# Altium schematic sheet Power and Data.SchDoc("Power and Data")
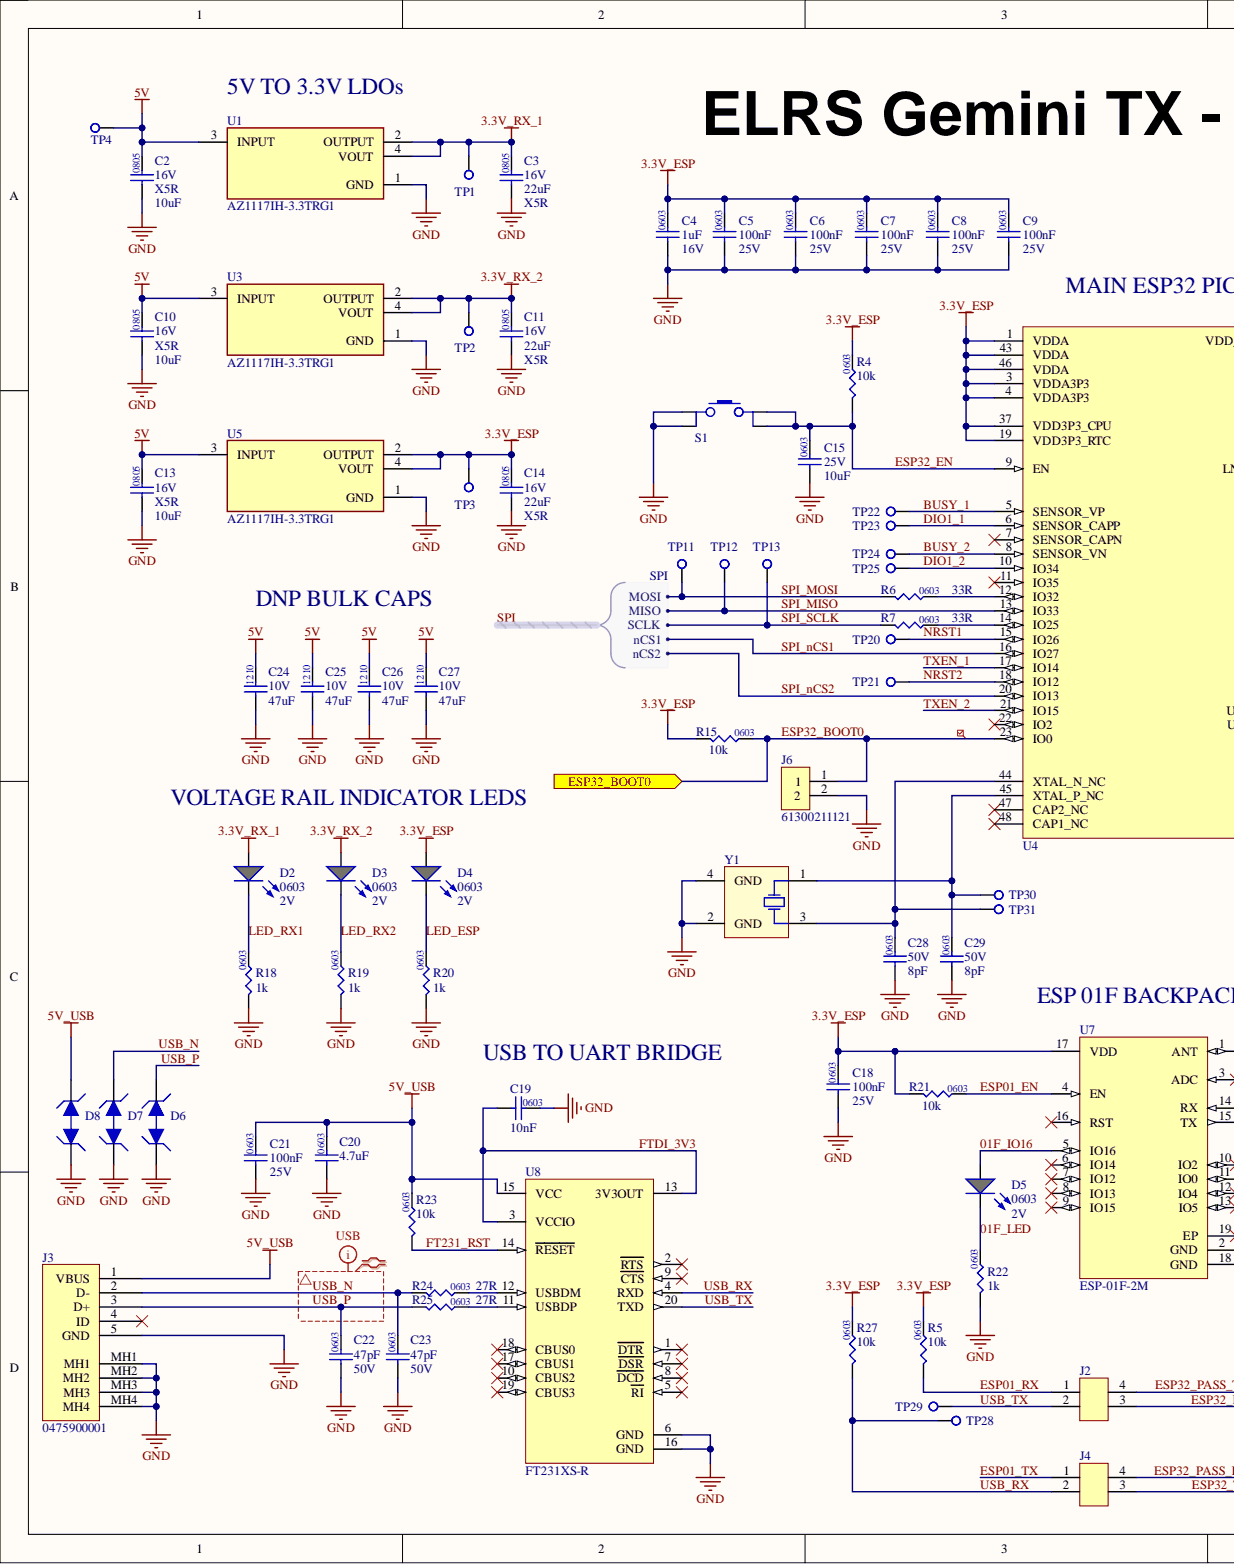
[diagram p0: Power and Data.SchDoc, left]
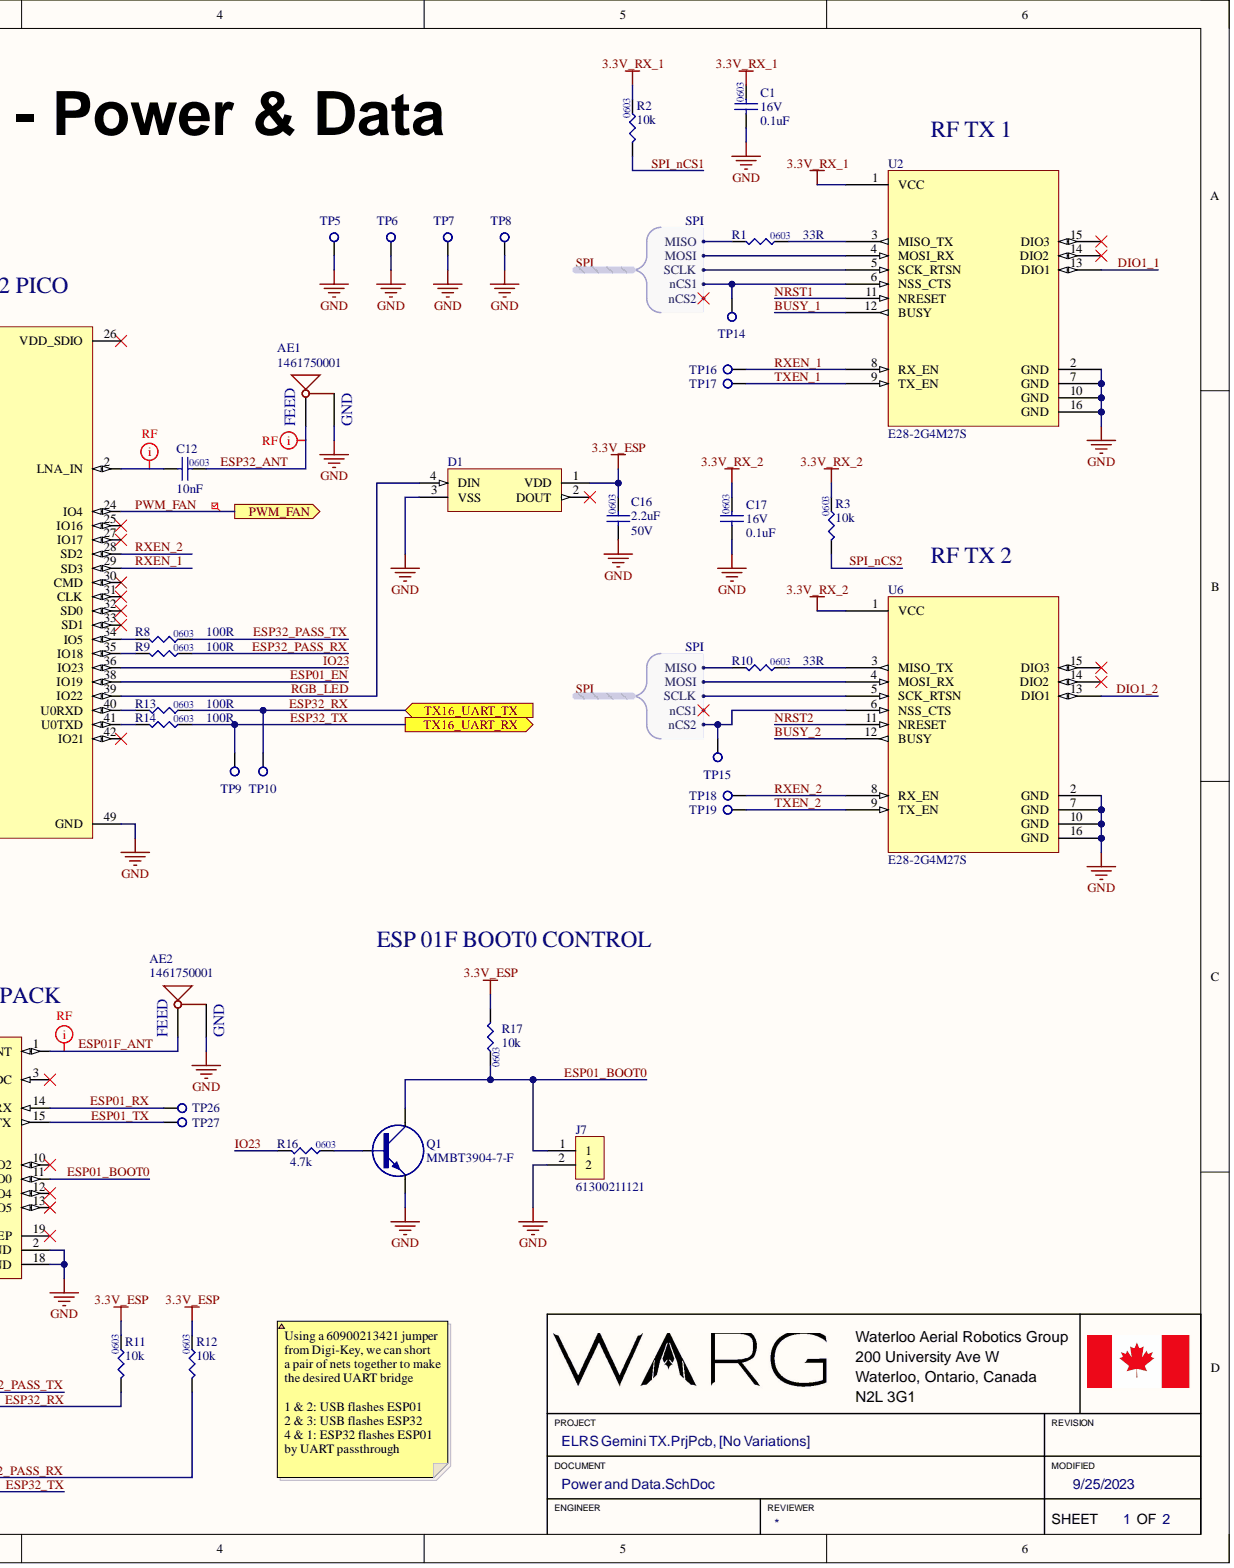
[diagram p1: Power and Data.SchDoc, right]

## Parts
AE1  1461750001
AE2  1461750001
C1  value 0.1uF  Capactitance: 0.1uF  Package: 0603  Voltage: 16V  (hidden comment: GCM188R71C104KA37D)
C2  value 10uF  Capacitance: 10uF  Package: 0805  Temperature Coefficient: X5R  Voltage: 16V  (hidden comment: CL21A106KOQNNNE)
C3  value 22uF  Capacitance: 22uF  Package: 0805  Temperature Coefficient: X5R  Voltage: 16V  (hidden comment: CL21A226MOQNNNE)
C4  value 1uF  Capacitance: 1uF  Package: 0603  Voltage: 16V  (hidden comment: GCM188R71C105KA64J)
C5  Capacitance: 100nF  Case/Package: 0603  Voltage Rating: 25V  (hidden comment: CL10B104KA8NNNC)
C6  Capacitance: 100nF  Case/Package: 0603  Voltage Rating: 25V  (hidden comment: CL10B104KA8NNNC)
C7  Capacitance: 100nF  Case/Package: 0603  Voltage Rating: 25V  (hidden comment: CL10B104KA8NNNC)
C8  Capacitance: 100nF  Case/Package: 0603  Voltage Rating: 25V  (hidden comment: CL10B104KA8NNNC)
C9  Capacitance: 100nF  Case/Package: 0603  Voltage Rating: 25V  (hidden comment: CL10B104KA8NNNC)
C10  value 10uF  Capacitance: 10uF  Package: 0805  Temperature Coefficient: X5R  Voltage: 16V  (hidden comment: CL21A106KOQNNNE)
C11  value 22uF  Capacitance: 22uF  Package: 0805  Temperature Coefficient: X5R  Voltage: 16V  (hidden comment: CL21A226MOQNNNE)
C12  value 10nF  Capacitance: 10nF  Package: 0603  (hidden comment: CC0603KRX7R7BB103)
C13  value 10uF  Capacitance: 10uF  Package: 0805  Temperature Coefficient: X5R  Voltage: 16V  (hidden comment: CL21A106KOQNNNE)
C14  value 22uF  Capacitance: 22uF  Package: 0805  Temperature Coefficient: X5R  Voltage: 16V  (hidden comment: CL21A226MOQNNNE)
C15  value 10uF  Case/Package: 0603  Voltage Rating: 25V  (hidden comment: GRM188R61E106KA73J)
C16  value 2.2uF  Package: 0603  Voltage Rating: 50V  (hidden comment: GRM188R61H225KE11D)
C17  value 0.1uF  Capactitance: 0.1uF  Package: 0603  Voltage: 16V  (hidden comment: GCM188R71C104KA37D)
C18  Capacitance: 100nF  Case/Package: 0603  Voltage Rating: 25V  (hidden comment: CL10B104KA8NNNC)
C19  value 10nF  Capacitance: 10nF  Package: 0603  (hidden comment: CC0603KRX7R7BB103)
C20  value 4.7uF  Capacitance: 4.7uF  Package: 0603  (hidden comment: GRM188C81E475KE11D)
C21  Capacitance: 100nF  Case/Package: 0603  Voltage Rating: 25V  (hidden comment: CL10B104KA8NNNC)
C22  value 47pF  Case/Package: 0603  Voltage Rating: 50V  (hidden comment: CL10C470JB8NNNC)
C23  value 47pF  Case/Package: 0603  Voltage Rating: 50V  (hidden comment: CL10C470JB8NNNC)
C24  value 47uF  Voltage Rating: 10V  (hidden comment: GRM32EC81A476KE19L)
C25  value 47uF  Voltage Rating: 10V  (hidden comment: GRM32EC81A476KE19L)
C26  value 47uF  Voltage Rating: 10V  (hidden comment: GRM32EC81A476KE19L)
C27  value 47uF  Voltage Rating: 10V  (hidden comment: GRM32EC81A476KE19L)
C28  Capacitance: 8pF  Package: 0603  Voltage Rating: 50V  (hidden comment: CC0603BRNPO9BN8R0)
C29  Capacitance: 8pF  Package: 0603  Voltage Rating: 50V  (hidden comment: CC0603BRNPO9BN8R0)
D1  COM-16347  (value not drawn)
D2  Case/Package: 0603  Forward Voltage: 2V  (hidden comment: LTST-C191KGKT)
D3  Case/Package: 0603  Forward Voltage: 2V  (hidden comment: LTST-C191KGKT)
D4  Case/Package: 0603  Forward Voltage: 2V  (hidden comment: LTST-C191KGKT)
D5  Case/Package: 0603  Forward Voltage: 2V  (hidden comment: LTST-C191KGKT)
D6  DESD5V0U1BA-7  (value not drawn)
D7  DESD5V0U1BA-7  (value not drawn)
D8  DESD5V0U1BA-7  (value not drawn)
J2  M20-9760246  (value not drawn)
J3  0475900001
J4  M20-9760246  (value not drawn)
J6  61300211121
J7  61300211121
Q1  MMBT3904-7-F
R1  value 33R  Case/Package: 0603  (hidden comment: RC0603FR-0733RL)
R2  value 10k  Package: 0603  Resistance: 10k  (hidden comment: RC0603FR-0710KL)
R3  value 10k  Package: 0603  Resistance: 10k  (hidden comment: RC0603FR-0710KL)
R4  value 10k  Package: 0603  Resistance: 10k  (hidden comment: RC0603FR-0710KL)
R5  value 10k  Package: 0603  Resistance: 10k  (hidden comment: RC0603FR-0710KL)
R6  value 33R  Case/Package: 0603  (hidden comment: RC0603FR-0733RL)
R7  value 33R  Case/Package: 0603  (hidden comment: RC0603FR-0733RL)
R8  Case Code (Imperial): 0603  Resistance: 100R  (hidden comment: RC0603FR-07100RL)
R9  Case Code (Imperial): 0603  Resistance: 100R  (hidden comment: RC0603FR-07100RL)
R10  value 33R  Case/Package: 0603  (hidden comment: RC0603FR-0733RL)
R11  value 10k  Package: 0603  Resistance: 10k  (hidden comment: RC0603FR-0710KL)
R12  value 10k  Package: 0603  Resistance: 10k  (hidden comment: RC0603FR-0710KL)
R13  Case Code (Imperial): 0603  Resistance: 100R  (hidden comment: RC0603FR-07100RL)
R14  Case Code (Imperial): 0603  Resistance: 100R  (hidden comment: RC0603FR-07100RL)
R15  value 10k  Package: 0603  Resistance: 10k  (hidden comment: RC0603FR-0710KL)
R16  value 4.7k  Case/Package: 0603  Resistance: 4.7k  (hidden comment: RC0603JR-074K7L)
R17  value 10k  Package: 0603  Resistance: 10k  (hidden comment: RC0603FR-0710KL)
R18  value 1k  Case/Package: 0603  (hidden comment: RC0603FR-071KL)
R19  value 1k  Case/Package: 0603  (hidden comment: RC0603FR-071KL)
R20  value 1k  Case/Package: 0603  (hidden comment: RC0603FR-071KL)
R21  value 10k  Package: 0603  Resistance: 10k  (hidden comment: RC0603FR-0710KL)
R22  value 1k  Case/Package: 0603  (hidden comment: RC0603FR-071KL)
R23  value 10k  Package: 0603  Resistance: 10k  (hidden comment: RC0603FR-0710KL)
R24  Package Name: 0603  (hidden comment: RC0603FR-0727RL)
R25  Package Name: 0603  (hidden comment: RC0603FR-0727RL)
R27  value 10k  Package: 0603  Resistance: 10k  (hidden comment: RC0603FR-0710KL)
S1  SWITCH_SPST_NO  (value not drawn)
TP1  RCWCTE  (value not drawn)
TP2  RCWCTE  (value not drawn)
TP3  RCWCTE  (value not drawn)
TP4  RCWCTE  (value not drawn)
TP5  RCWCTE  (value not drawn)
TP6  RCWCTE  (value not drawn)
TP7  RCWCTE  (value not drawn)
TP8  RCWCTE  (value not drawn)
TP9  TESTINGPOINT_1MM  (value not drawn)
TP10  TESTINGPOINT_1MM  (value not drawn)
TP11  TESTINGPOINT_1MM  (value not drawn)
TP12  TESTINGPOINT_1MM  (value not drawn)
TP13  TESTINGPOINT_1MM  (value not drawn)
TP14  TESTINGPOINT_1MM  (value not drawn)
TP15  TESTINGPOINT_1MM  (value not drawn)
TP16  TESTINGPOINT_1MM  (value not drawn)
TP17  TESTINGPOINT_1MM  (value not drawn)
TP18  TESTINGPOINT_1MM  (value not drawn)
TP19  TESTINGPOINT_1MM  (value not drawn)
TP20  TESTINGPOINT_1MM  (value not drawn)
TP21  TESTINGPOINT_1MM  (value not drawn)
TP22  TESTINGPOINT_1MM  (value not drawn)
TP23  TESTINGPOINT_1MM  (value not drawn)
TP24  TESTINGPOINT_1MM  (value not drawn)
TP25  TESTINGPOINT_1MM  (value not drawn)
TP26  TESTINGPOINT_1MM  (value not drawn)
TP27  TESTINGPOINT_1MM  (value not drawn)
TP28  TESTINGPOINT_1MM  (value not drawn)
TP29  TESTINGPOINT_1MM  (value not drawn)
TP30  TESTINGPOINT_1MM  (value not drawn)
TP31  TESTINGPOINT_1MM  (value not drawn)
U1  AZ1117IH-3.3TRG1  Output Voltage: 3.3V
U2  E28-2G4M27S
U3  AZ1117IH-3.3TRG1
U4  ESP32-PICO-D4  (value not drawn)
U5  AZ1117IH-3.3TRG1
U6  E28-2G4M27S
U7  ESP-01F-2M
U8  FT231XS-R
Y1  RH100-40.000-6-F-1010-EXT-TR  (value not drawn)

## Ports
ESP32_BOOT0, PWM_FAN, TX16_UART_RX, TX16_UART_TX

## Nets
3.3V_ESP: C4.2 C5.2 C6.2 C7.2 C8.2 C9.2 C14.2 C16.2 C18.2 D1.1(VDD) D4.1 R4.2 R5.2 R11.2 R12.2 R15.1 R17.1 R21.1 R27.2 TP3.1 U4.1(VDDA) U4.3(VDDA3P3) U4.4(VDDA3P3) U4.19(VDD3P3_RTC) U4.37(VDD3P3_CPU) U4.43(VDDA) U4.46(VDDA) U5.2(OUTPUT) U5.4(VOUT) U7.17(VDD)
3.3V_RX_1: C1.2 C3.2 D2.1 R2.2 TP1.1 U1.2(OUTPUT) U1.4(VOUT) U2.1(VCC)
3.3V_RX_2: C11.2 C17.2 D3.1 R3.2 TP2.1 U3.2(OUTPUT) U3.4(VOUT) U6.1(VCC)
5V: C2.2 C10.2 C13.2 C24.2 C25.2 C26.2 C27.2 TP4.1 U1.3(INPUT) U3.3(INPUT) U5.3(INPUT)
5V_USB: C20.2 C21.2 D8.2 J3.1(VBUS) R23.2 U8.15(VCC)
GND: AE1.2 AE2.2 C1.1 C2.1 C3.1 C4.1 C5.1 C6.1 C7.1 C8.1 C9.1 C10.1 C11.1 C13.1 C14.1 C15.1 C16.1 C17.1 C18.1 C19.2 C20.1 C21.1 C22.1 C23.1 C24.1 C25.1 C26.1 C27.1 C28.1 C29.1 D1.3(VSS) D6.1 D7.1 D8.1 J3.5(GND) J3.MH1 J3.MH2 J3.MH3 J3.MH4 J6.2 J7.2 Q1.2 R18.1 R19.1 R20.1 R22.1 S1.1 S1.2 TP5.1 TP6.1 TP7.1 TP8.1 U1.1(GND) U2.2(GND) U2.7(GND) U2.10(GND) U2.16(GND) U3.1(GND) U4.49(GND) U5.1(GND) U6.2(GND) U6.7(GND) U6.10(GND) U6.16(GND) U7.2(GND) U7.18(GND) U8.6(GND) U8.16(GND) Y1.2(GND) Y1.4(GND)
01F_IO16: D5.1 U7.5(IO16)
01F_LED: D5.2 R22.2
BUSY_1: TP22.1 U2.12(BUSY) U4.5(SENSOR_VP)
BUSY_2: TP24.1 U4.8(SENSOR_VN) U6.12(BUSY)
DIO1_1: TP23.1 U2.13(DIO1) U4.6(SENSOR_CAPP)
DIO1_2: TP25.1 U4.10(IO34) U6.13(DIO1)
ESP01_BOOT0: J7.1 Q1.3 R17.2 U7.11(IO0)
ESP01_EN: R21.2 U4.38(IO19) U7.4(EN)
ESP01_RX: J2.1 R5.1 TP26.1 U7.14(RX)
ESP01_TX: J4.1 TP27.1 U7.15(TX)
ESP01F_ANT: AE2.1 U7.1(ANT)
ESP32_ANT: AE1.1 C12.2
ESP32_BOOT0: J6.1 R15.2 U4.23(IO0) ; port ESP32_BOOT0
ESP32_EN: C15.2 R4.1 S1.3 S1.4 U4.9(EN)
ESP32_PASS_RX: J4.4 R9.2 R12.1
ESP32_PASS_TX: J2.4 R8.2
ESP32_RX: J2.3 R11.1 R13.2 TP10.1 ; port TX16_UART_TX
ESP32_TX: J4.3 R14.2 TP9.1 ; port TX16_UART_RX
FT231_RST: R23.1 U8.14(RESET)
FTDI_3V3: C19.1 U8.3(VCCIO) U8.13(3V3OUT)
IO23: R16.1 U4.36(IO23)
LED_ESP: D4.2 R20.2
LED_RX1: D2.2 R18.2
LED_RX2: D3.2 R19.2
NRST1: TP20.1 U2.11(NRESET) U4.15(IO26)
NRST2: TP21.1 U4.18(IO12) U6.11(NRESET)
PWM_FAN: U4.24(IO4) ; port PWM_FAN
RGB_LED: D1.4(DIN) U4.39(IO22)
RXEN_1: TP16.1 U2.8(RX_EN) U4.29(SD3)
RXEN_2: TP18.1 U4.28(SD2) U6.8(RX_EN)
SPI.MISO: R1.1 R10.1 TP12.1 U4.13(IO33)
SPI.MOSI: R6.1 TP11.1 U2.4(MOSI_RX) U6.4(MOSI_RX)
SPI.nCS1: R2.1 TP14.1 U2.6(NSS_CTS) U4.16(IO27)
SPI.nCS2: R3.1 TP15.1 U4.20(IO13) U6.6(NSS_CTS)
SPI.SCLK: R7.1 TP13.1 U2.5(SCK_RTSN) U6.5(SCK_RTSN)
TXEN_1: TP17.1 U2.9(TX_EN) U4.17(IO14)
TXEN_2: TP19.1 U4.21(IO15) U6.9(TX_EN)
USB_N: C23.2 D7.2 J3.2(D-) R24.1
USB_P: C22.2 D6.2 J3.3(D+) R25.1
USB_RX: J4.2 R27.1 TP28.1 U8.4(RXD)
USB_TX: J2.2 TP29.1 U8.20(TXD)
NetC12_1: C12.1 U4.2(LNA_IN)
NetC28_2: C28.2 TP31.1 U4.44(XTAL_N_NC) Y1.3
NetC29_2: C29.2 TP30.1 U4.45(XTAL_P_NC) Y1.1
NetQ1_1: Q1.1 R16.2
NetR1_2: R1.2 U2.3(MISO_TX)
NetR6_2: R6.2 U4.12(IO32)
NetR7_2: R7.2 U4.14(IO25)
NetR8_1: R8.1 U4.34(IO5)
NetR9_1: R9.1 U4.35(IO18)
NetR10_2: R10.2 U6.3(MISO_TX)
NetR13_1: R13.1 U4.40(U0RXD)
NetR14_1: R14.1 U4.41(U0TXD)
NetR24_2: R24.2 U8.12(USBDM)
NetR25_2: R25.2 U8.11(USBDP)

Not connected: D1 2(DOUT); J3 4(ID); U2 14(DIO2) 15(DIO3); U4 7(SENSOR_CAPN) 11(IO35) 22(IO2) 25(IO16) 26(VDD_SDIO) 27(IO17) 30(CMD) 31(CLK) 32(SD0) 33(SD1) 42(IO21) 47(CAP2_NC) 48(CAP1_NC); U6 14(DIO2) 15(DIO3); U7 3(ADC) 6(IO14) 7(IO12) 8(IO13) 9(IO15) 10(IO2) 12(IO4) 13(IO5) 16(RST) 19(EP); U8 1(DTR) 2(RTS) 5(RI) 7(DSR) 8(DCD) 9(CTS) 10(CBUS2) 17(CBUS1) 18(CBUS0) 19(CBUS3)

## Library data of the parts
AE1 AE2 = CMP-00141-3: RF ANT 2.4GHZ/5.4GHZ CHIP SLD; Component Kind: Standard; Library Name: WARG
C1 C17 = CMP-001-00020-5: CAP CER 0.1uF 16V X7R 0603; Component Kind: Standard; Temperature Coefficient: X7R; Library Name: WARG
C2 C10 C13 = CMP-001-00016-4: CAP CER 10UF 16V X5R 0805; Component Kind: Standard; Library Name: WARG
C3 C11 C14 = CMP-001-00015-4: CAP CER 22UF 16V X5R 0805; Component Kind: Standard; Library Name: WARG
C4 = CMP-001-00007-6: CAP CER 1uF 16V X7R 0603; Component Kind: Standard; Library Name: WARG
C5-C9 C18 C21 = CMP-00057-1: CAP CER 0.1UF 25V X7R 0603; Tolerance: 10%; Component Kind: Standard; Dielectric: X7R; Library Name: WARG
C12 C19 = CMP-001-00004-10: CAP CER 10nF 16V X7R 0603; Component Kind: Standard; Voltage: 16V; Library Name: WARG
C15 = CMP-00056-3: CAP CER 10UF 25V X5R 0603; Component Kind: Standard; Temperature Coefficient: X5R; Library Name: WARG
C16 = CMP-001-00028-4: CAP CER 2.2UF 50V X5R 0603; Manufacturer 1: Murata Electronics; Tolerance: 10%; Component Kind: Standard; Manufacturer Part Number 1: GRM188R61H225KE11D; Mounting Type: Surface Mount; Supplier 1: Digi-Key; Supplier Part Number 1: 490-10733-1-ND; Temperature Coefficient: X5R; Library Name: WARG
C20 = CMP-001-00002-8: CAP CER 4.7uF 25V X6S 0603; Component Kind: Standard; Voltage: 25V; Library Name: WARG
C22 C23 = CMP-00133-1: CAP CER 47PF ±5% 50V C0G/NP0 0603; Component Kind: Standard; Library Name: WARG
C24-C27 = CMP-001-00040-2: CAP CER 47UF 10V X6S 1210; Component Kind: Standard; Library Name: WARG
C28 C29 = CMP-00158-1: CAP CER 8PF ±0.1PF 50V C0G, NP0 0603; Component Kind: Standard; Mounting Type: SMD; Operating Temperature: -55°C ~ 125°C; Tolerance: ±0.1pF; Library Name: WARG
D1 = CMP-00136-1: ADDRESS LED RED/GRN/BLUE; Component Kind: Standard; Library Name: WARG
D2-D5 = CMP-001-00018-6: LED GREEN 2V 0603; Forward Current: 20mA; Color: Green; Component Kind: Standard; Library Name: WARG
D6-D8 = CMP-00145-5: TVS DIODE, 5V V(RWM), Bidirectional, 1 Element, Silicon; Diode Type: TRANS VOLTAGE SUPPRESSOR; Max Breakdown Voltage: 9.5V; Max Power Dissipation: 250mW; Min Breakdown Voltage: 5.5V; Component Kind: Standard; Max Operating Temperature: 150°C; Min Operating Temperature: -65°C; Polarity: Bidirectional; Rep Pk Reverse Voltage-Max: 5V; Library Name: WARG
J2 J4 = CMP-00130-1: CONN HEADER SMD 4POS 2.54MM; Component Kind: Standard; Mounting Type: SMD; Library Name: WARG
J3 = CMP-00106-5: RightAngle SMT TypeABVersion 2.0 Micro USB Conn Skt; 30Vac; 1.8A 47650 MICRO-USB; Component Kind: Standard; Connector Type: USB; Mount: Surface Mount; Library Name: WARG
J6 J7 = CMP-00165-1: CONN HEADER VERT 2POS 2.54MM; Component Kind: Standard; Mounting Type: TH; Operating Temperature Range: -40°C ~ 105°C; Library Name: WARG
Q1 = CMP-013-00011-1: NPN Ic=200mA Vceo=40V hfe=100~300 fT=310MHz P=300mW SOT-23; Collector Base Voltage (VCBO): 60V; Collector Emitter Breakdown Voltage: 40V; Collector Emitter Saturation Voltage: 300mV; Continuous Collector Current: 200mA; Emitter Base Voltage (VEBO): 6V; Power Dissipation: 350mW; Case/Package: SOT-23; Collector Emitter Voltage (VCEO): 40V; Collector-emitter Voltage-Max: 300mV; Component Kind: Standard; Height: 1mm; Max Breakdown Voltage: 40V; Max Collector Current: 200mA; Polarity: NPN; Library Name: WARG
R1 R6 R7 R10 = CMP-00151-1: RES 33 OHM ±1% 1/10W 0603; Power: 100mW; Component Kind: Standard; Tolerance: 1%; Library Name: WARG
R2-R5 R11 R12 R15 R17 R21 R23 R27 = CMP-009-00006-4: RES 10K OHM 1% 1/10W 0603; Component Kind: Standard; Power: 1/10W; Library Name: WARG
R8 R9 R13 R14 = CMP-00022-3: RES 100 OHM 1% 1/10W 0603; Max Power Dissipation: 100mW; Temperature Coefficient: 100ppm/°C; Voltage Rating: 50V; Case Code (Metric): 1608; Case/Package: 0603; Component Kind: Standard; Construction: Rectangular; Depth: 0.8mm; Features: Moisture Resistant; Height: 0.45mm; Lead Free: Lead Free; Length: 1.6mm; Max Operating Temperature: 155°C; Military Standard: Not; Min Operating Temperature: -55°C; Number of Pins: 2; Number of Terminations: 2; Packaging: Tape and Reel; Power Rating: 100mW; REACH SVHC: Unknown; Resistance Tolerance: 1%; Resistor Type: Thick Film; RoHS Compliant: Yes; Series: RC; Size Code: 0603; Technology: THICK FILM; Termination: SMD/SMT; Tolerance: 1%; Voltage Rating (DC): 50V; Width: 0.8mm; Library Name: WARG
R16 = CMP-009-00019-3: RES 4.7K OHM 5% 1/10W 0603; Power Rating: 100mW; Voltage Rating (DC): 50V; Component Kind: Standard; Resistance Tolerance: 5%; Library Name: WARG
R18-R20 R22 = CMP-009-00012-3: RES 1k OHM 1% 1/10W 0603; Component Kind: Standard; Power: 1/10W; Library Name: WARG
R24 R25 = CMP-00138-1: RES 27 OHM ±1 1/10W 0603; Resistance: 27R; Tolerance: 1%; Component Kind: Standard; Max Operating Temperature: 155°C; Min Operating Temperature: -55°C; Mounting Type: SMD; Library Name: WARG
S1 = CMP-011-00000-4: SWITCH TACTILE SPST-NO 0.05A 12V; Manufacturer Part Number: TL3301NF160QG/TR; Component Kind: Standard; Mounting Type: Surface Mount; Supplier: Digi-Key; Supplier Part Number: EG1867CT-ND; Library Name: WARG
TP1-TP8 = CMP-00028-00003-1: SMT Test Point; Component Kind: Standard; Mount: Surface Mount; Library Name: WARG
TP9-TP31 = CMP-00028-00002-1: Testing Point 1mm Radius; Component Kind: Standard; Radius: 1mm; Library Name: WARG
U1 U3 U5 = CMP-00132-1: Linear Voltage Regulator IC Positive Fixed 1 Output 3.3V 800mA SOT-223; Dropout Voltage: 1.2V; Min Current Limit: 1A; Number of Regulators: 1; Case/Package: TO-261-4; Component Kind: Standard; Max Operating Temperature: 125°C; Min Operating Temperature: -40°C; Mounting Technology: Surface Mount; Output Current: 800mA; Packaging: Cut Tape; Pins: 3; RoHS Compliant: Yes; Series: -; Library Name: WARG
  U3 U5: Output Voltage: 3.3V
U2 U6 = CMP-00131-1: SX1280 2.4GHz 27dBm SPI High Speed LoRa Module; Component Kind: Standard; Library Name: WARG
U4 = CMP-00128-2: RF TXRX MOD WIFI SURFACE MOUNT; Component Kind: Standard; Mounting Type: SMD; Package Name: 48-SMD Module; Library Name: WARG
U7 = CMP-00127-1: -90dBm 16dBm ESP8285 External Antenna 2.4GHz - WiFi Modules ROHS; Component Kind: Standard; Library Name: WARG
U8 = CMP-00129-4: IC USB SERIAL FULL UART 20SSOP; Component Kind: Standard; Mounting Type: SMD; Package Name: 20-SSOP; Library Name: WARG
Y1 = CMP-00157-1: CRYSTAL 40.0000MHZ 6PF SMD; Component Kind: Standard; Mounting Type: SMD; Operating Temperature: -40°C ~ 85°C; Library Name: WARG
